# Altium schematic sheet 11_5V_RAIL_ADC.SchDoc(11_5V_RAIL_ADC)
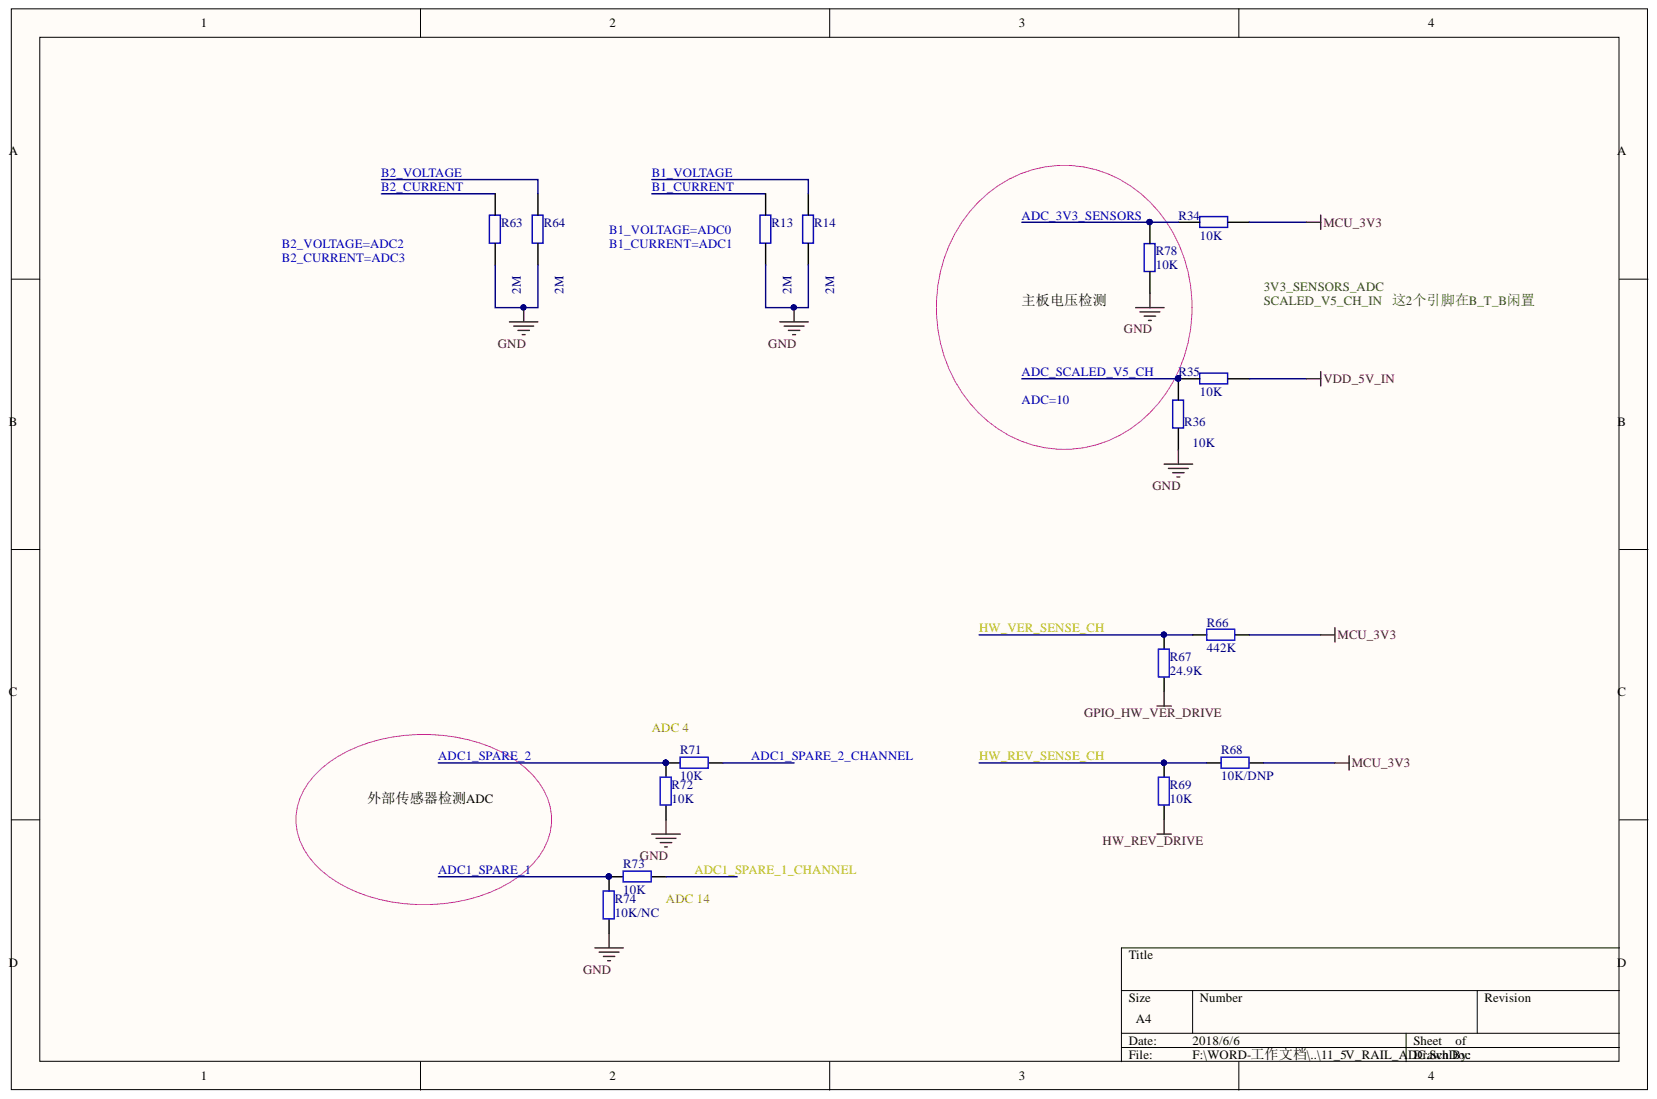
[diagram p0: 11_5V_RAIL_ADC.SchDoc]

## Parts
R13  2M  [0402R]
R14  2M  [0402R]
R34  10K  [0402R]
R35  10K  [0402R]
R36  10K  [0402R]
R63  2M  [0402R]
R64  2M  [0402R]
R66  442K  [R0402]
R67  24.9K  [R0402]
R68  10K/DNP  [R0402]
R69  10K  [R0402]
R71  10K  [R0402]
R72  10K  [R0402]
R73  10K  [R0402]
R74  10K/NC  [R0402]
R78  10K  [0402R]

## Nets
GND: R13.2 R14.2 R36.1 R63.2 R64.2 R72.1 R74.1 R78.2
GPIO_HW_VER_DRIVE: R67.1
HW_REV_DRIVE: R69.1
MCU_3V3: R34.2 R66.2 R68.2
VDD_5V_IN: R35.2
ADC1_SPARE_1: R73.1 R74.2
ADC1_SPARE_1_CHANNEL: R73.2
ADC1_SPARE_2: R71.1 R72.2
ADC1_SPARE_2_CHANNEL: R71.2
ADC_3V3_SENSORS: R34.1 R78.1
ADC_SCALED_V5_CH: R35.1 R36.2
B1_CURRENT: R13.1
B1_VOLTAGE: R14.1
B2_CURRENT: R63.1
B2_VOLTAGE: R64.1
HW_REV_SENSE_CH: R68.1 R69.2
HW_VER_SENSE_CH: R66.1 R67.2
3V3_SENSORS_ADC:
ADC 4:
ADC 14:
ADC=10:
B1_CURRENT=ADC1:
B1_VOLTAGE=ADC0:
B2_CURRENT=ADC3:
B2_VOLTAGE=ADC2:
SCALED_V5_CH_IN:
主板电压检测:
外部传感器检测ADC:
这2个引脚在B_T_B闲置:

## Library data of the parts
R13 R14 R63 R64 = 0402R10K: 0402R10K 1%; Component Kind: Standard; Library Name: CUAV.SchLib
R34-R36 R78 = 0402R100R: 0402R100R 1%; Component Kind: Standard; Library Name: CUAV.SchLib
R66-R69 R71-R74 = Res2: Resistor; Value: 1K; Component Kind: Standard; LatestRevisionDate: 17-Jul-2002; LatestRevisionNote: Re-released for DXP Platform.; Library Name: Miscellaneous Devices.IntLib; PackageDescription: Axial Device, Thru-Hole; 2 Leads; 0.4 in Pin Spacing; PackageReference: AXIAL-0.4; Published: 8-Jun-2000; Signal Integrity: Res; Simulation: RESISTOR; Publisher: Altium Limited
